# Altium schematic sheet Extra0_arduino.SchDoc
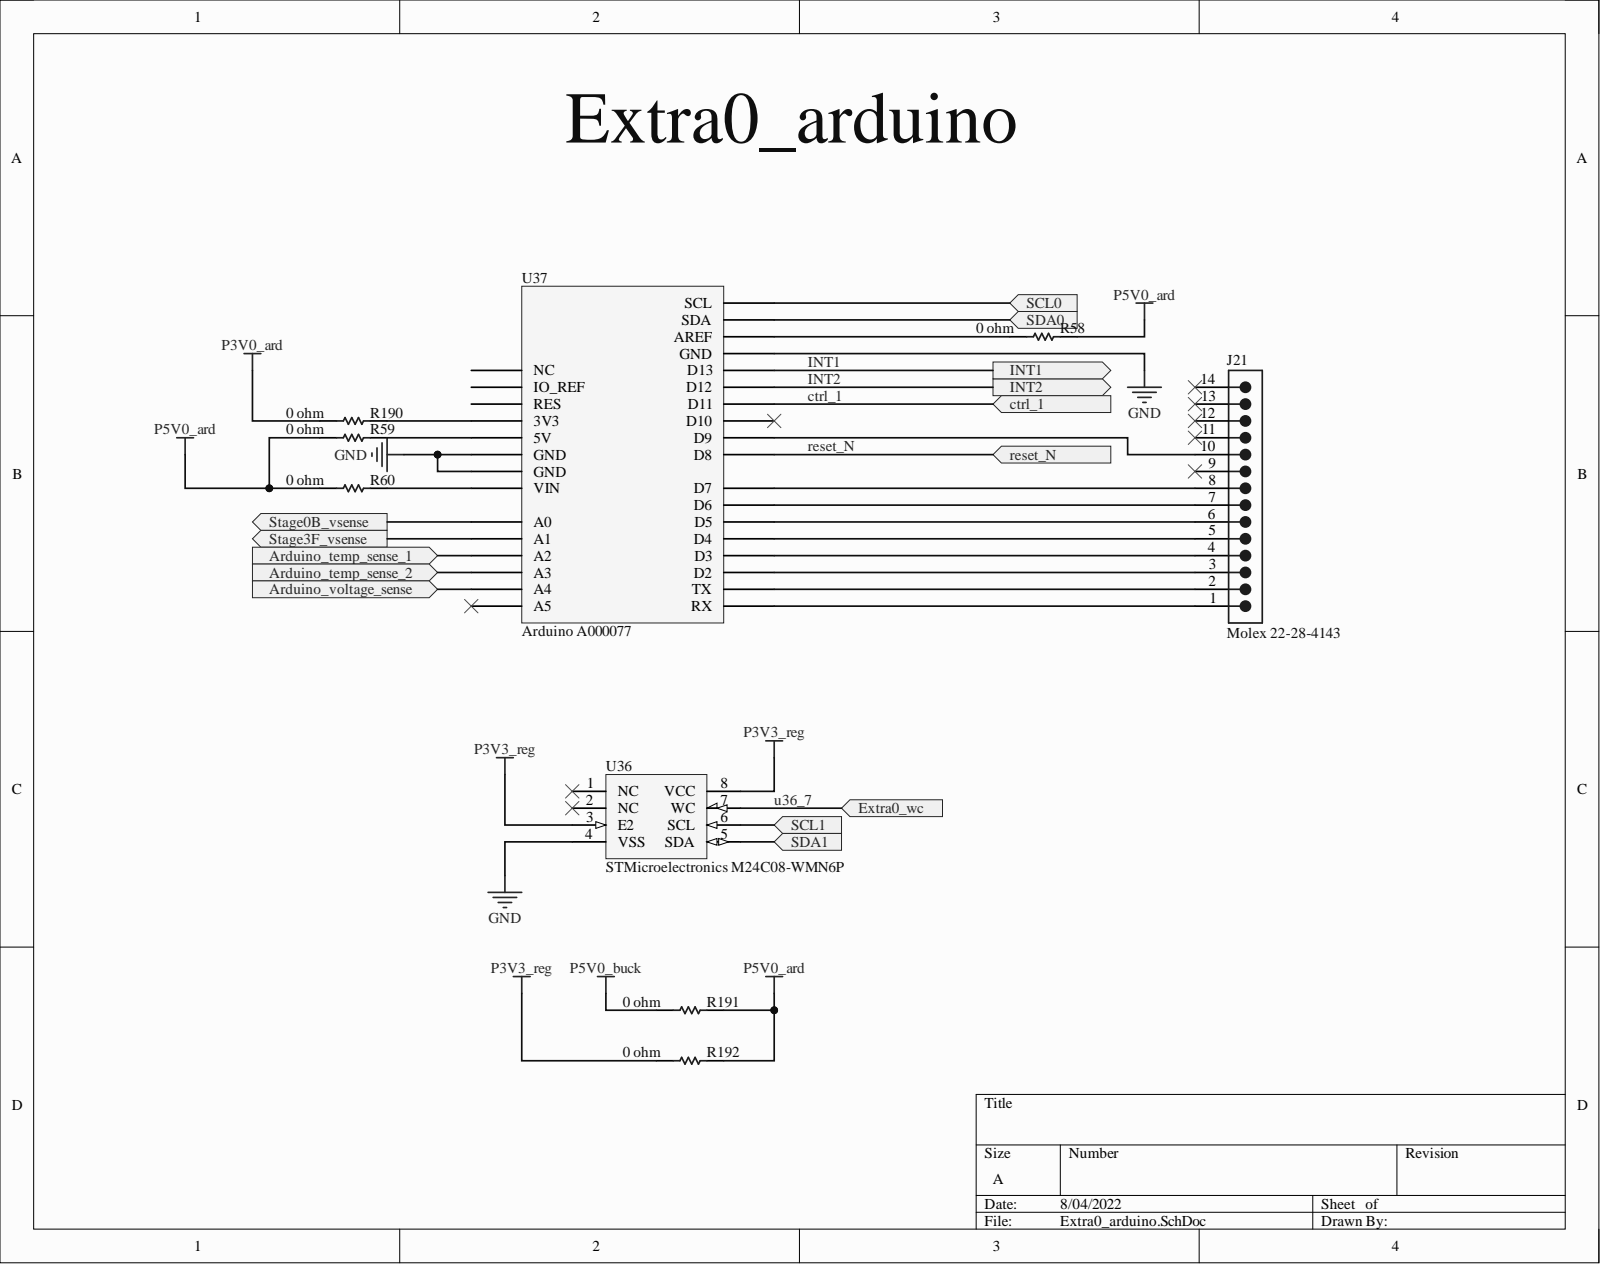
[diagram p0: Extra0_arduino.SchDoc]

## Parts
J21  Molex 22-28-4143  Manufacturer Part Number: 22-28-4143  [PCB-h4uy032htge4972uzyrj-1]
R58  0 ohm  [RESC2013X60X35NL10T20]
R59  0 ohm  [RESC2013X60X35NL10T20]
R60  0 ohm  [RESC2013X60X35NL10T20]
R190  0 ohm  [RESC2013X60X35NL10T20]
R191  0 ohm  [RESC2013X60X35NL10T20]
R192  0 ohm  [RESC2013X60X35NL10T20]
U36  STMicroelectronics M24C08-WMN6P  Manufacturer Part Number: M24C08-WMN6P  [PCB-7jduzr78fb9pl04bmnbf-1]
U37  Arduino A000077  Manufacturer Part Number: A000077  [PCB-q4pvn1zbqrbs7w2g4x4d-1]

## Ports
Arduino_temp_sense_1, Arduino_temp_sense_2, Arduino_voltage_sense, ctrl_1, Extra0_wc, INT1, INT2, reset_N, SCL0, SCL1, SDA0, SDA1, Stage0B_vsense, Stage3F_vsense

## Nets
GND: U36.4(VSS) U37.GND
P3V0_ard: R190.1
P3V3_reg: R192.1 U36.3(E2) U36.8(VCC)
P5V0_ard: R58.2 R59.1 R60.1 R191.2 R192.2
P5V0_buck: R191.1
Arduino_temp_sense_1: U37.A2 ; port Arduino_temp_sense_1
Arduino_temp_sense_2: U37.A3 ; port Arduino_temp_sense_2
Arduino_voltage_sense: U37.A4 ; port Arduino_voltage_sense
ctrl_1: U37.D11 ; port ctrl_1
INT1: U37.D13 ; port INT1
INT2: U37.D12 ; port INT2
reset_N: U37.D8 ; port reset_N
SCL0: U37.SCL ; port SCL0
SCL1: U36.6(SCL) ; port SCL1
SDA0: U37.SDA ; port SDA0
SDA1: U36.5(SDA) ; port SDA1
Stage0B_vsense: U37.A0 ; port Stage0B_vsense
Stage3F_vsense: U37.A1 ; port Stage3F_vsense
u36_7: U36.7(WC) ; port Extra0_wc
NetJ21_1: J21.1 U37.RX
NetJ21_2: J21.2 U37.tX(TX)
NetJ21_3: J21.3 U37.D2
NetJ21_4: J21.4 U37.D3
NetJ21_5: J21.5 U37.D4
NetJ21_6: J21.6 U37.D5
NetJ21_7: J21.7 U37.D6
NetJ21_8: J21.8 U37.D7
NetJ21_10: J21.10 U37.D9
NetR58_1: R58.1 U37.AREF
NetR59_2: R59.2 U37.5V
NetR60_2: R60.2 U37.VIN
NetR190_2: R190.2 U37.3V3

Not connected: J21 9 11 12 13 14; U36 1(NC) 2(NC); U37 A5 D10 IO_REF NC RES

## Library data of the parts
J21 = CMP-00bbc9b51b14df7c-3: KK® 254 Breakaway Header, Vertical, 14 Circuits, 0.38µm Gold (Au) Selective Plating, Mating Pin Length 6.09mm; Current Rating: 4.00 A; Gender: Male; Glow Wire Compliant: Non-Compliant; Lead-Free Status: Contains Lead; Number of Circuits: 14; Pin Pitch: 2.54 mm; Voltage Rating (DC): 250 V; Color: Black; Component Kind: Standard; Contact Material: Brass; Contact Plating: Gold; Flammability Rating: UL 94 V-0; Halogen Free Status: Not Low Halogen; Housing Color: Black; Housing Material: Thermoplastic; Library Name: My Personal Space; Lifecycle Status: Active; Mounting Style: Through Hole; Number of Contacts: 14; Number of Positions: 14; Number of Rows: 1; Octopart URL: http://octopart.com/22-28-4143-molex-278217; OctopartID: 00bbc9b51b14df7c; Orientation: Vertical; Packaging: Bulk; RoHS: Compliant; Size-Height: 2.29 mm; Size-Length: 35.6 mm; Size-Width: 2.49 mm
R58-R60 R190-R192 = CMP-5eab975428dd816c-2: Res Thick Film 0805 0 Ohm Jumper Molded SMD T/R; Manufacturer Part Number: CRG0805ZR; Case/Package (SI): 2012; ELV Compliant: Compliant; Power Rating: 125 mW; Resistance: 0.00 Ω; Case/Package: 0805; Component Kind: Standard; Library Name: My Personal Space; Lifecycle Status: Active; Mounting Style: Surface Mount; Octopart URL: https://octopart.com/crg0805zr-te+connectivity-16077584; OctopartID: 5eab975428dd816c; Packaging: Tape & Reel (TR); RoHS: Compliant
U36 = CMP-87a04ea04ab85867-3: M24C08 Series 8 Kb (1K x 8) 2.5 V SMT Serial I²C Bus EEPROM - SOIC-8; Access Time: 900 ns; Clock Speed: 400 kHz (max), 400 kHz; Frequency: 400 kHz; Lead-Free Status: Lead Free; Memory Size: 1000 B; Supply Voltage (DC): 5.50 V (max), 2.50 V (min); Case/Package: SO; Component Kind: Standard; Library Name: My Personal Space; Lifecycle Status: Active; Mounting Style: Surface Mount; Number of Pins: 8; Octopart URL: https://octopart.com/m24c08-wmn6p-stmicroelectronics-714878; OctopartID: 87a04ea04ab85867; Operating Temperature: -40.0 °C to 85.0 °C; Packaging: Tube; REACH SVHC Compliance: No SVHC; RoHS: Compliant
U37 = CMP-cdf305b1a0451234-27: Arduino Proto Shield Rev3 assembled; Component Kind: Standard; Library Name: My Personal Space; Octopart URL: http://octopart.com/a000077-arduino-21514448; OctopartID: cdf305b1a0451234; REACH SVHC Compliance: No SVHC; RoHS: Compliant
